# Altium schematic sheet SCH.SchDoc(SCH)
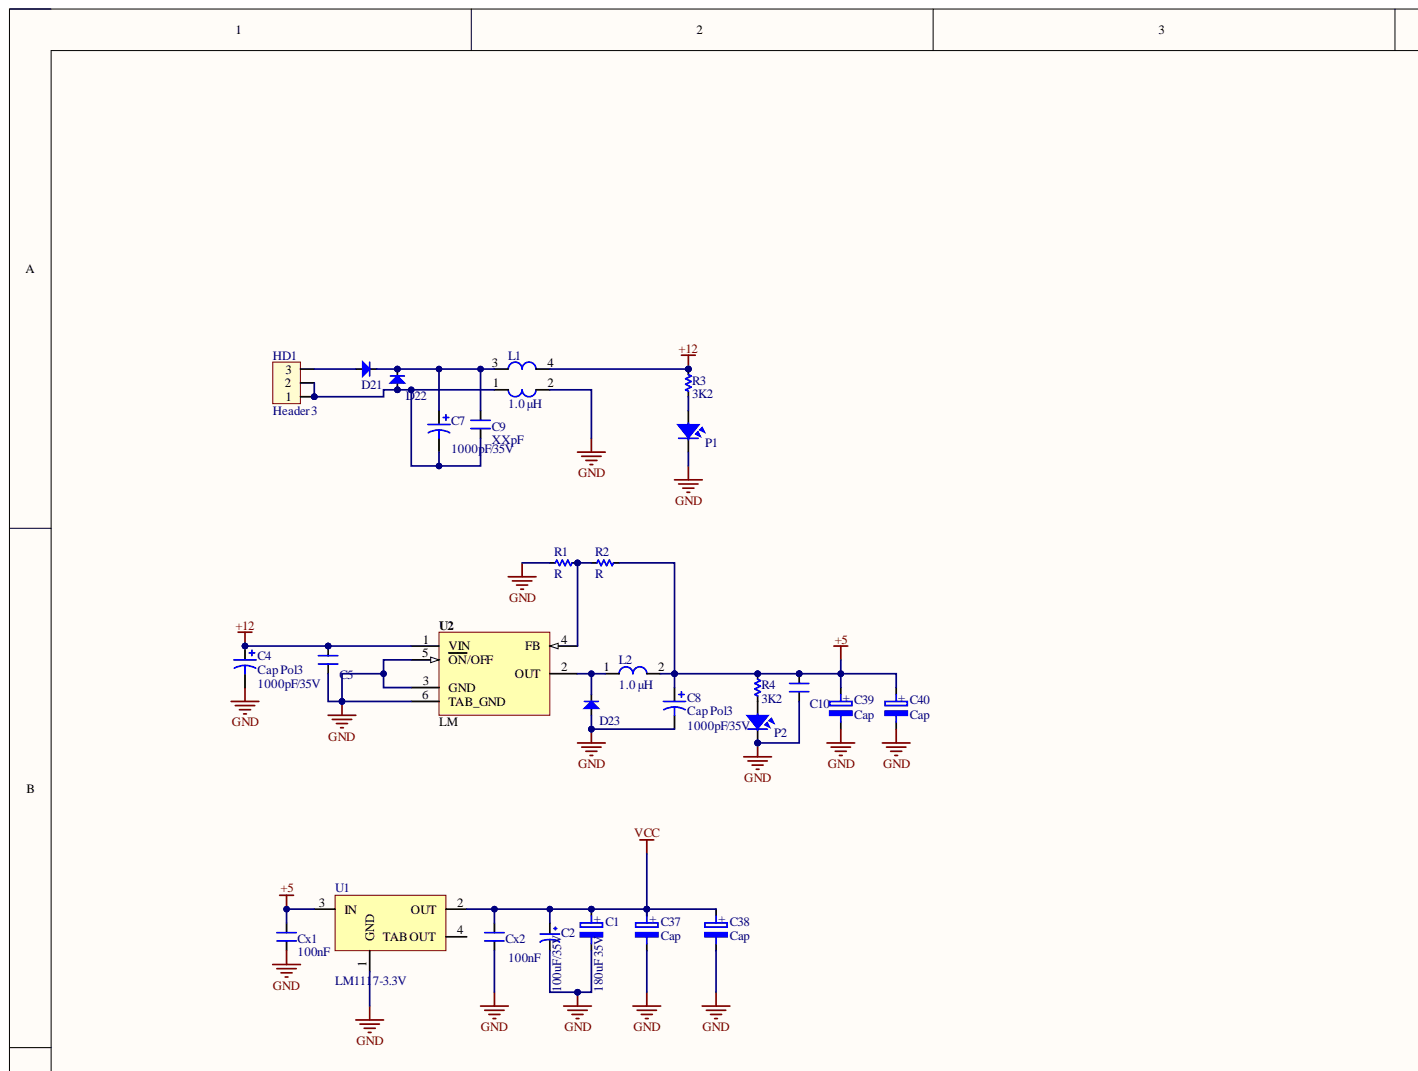
[diagram p0: SCH.SchDoc, top left]
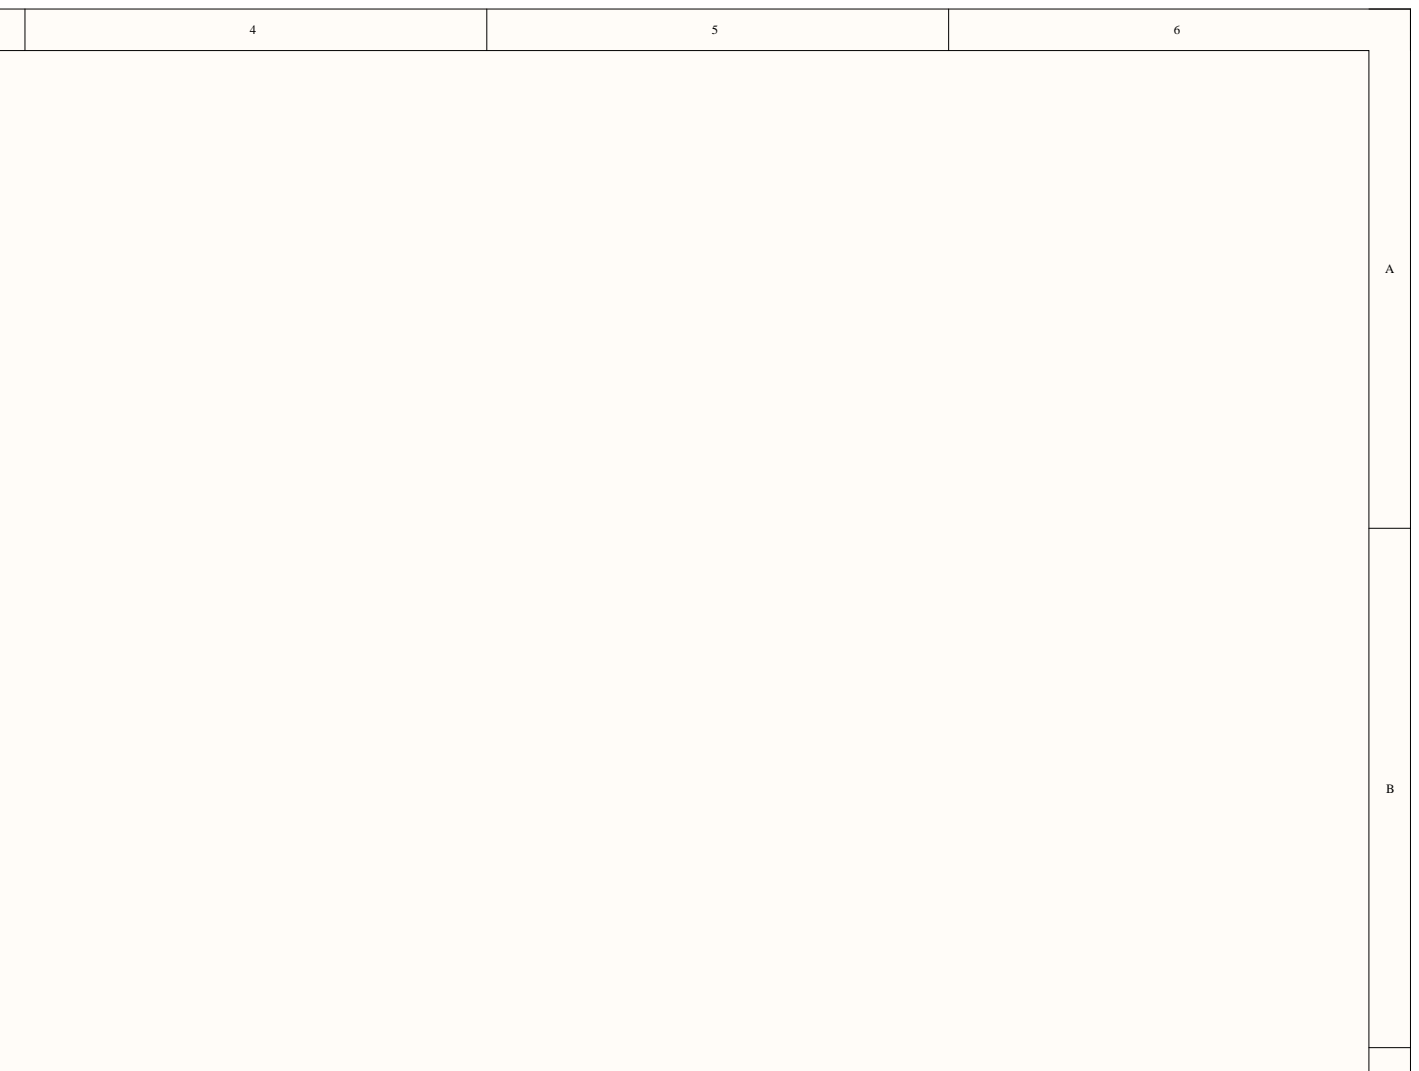
[diagram p1: SCH.SchDoc, top right]
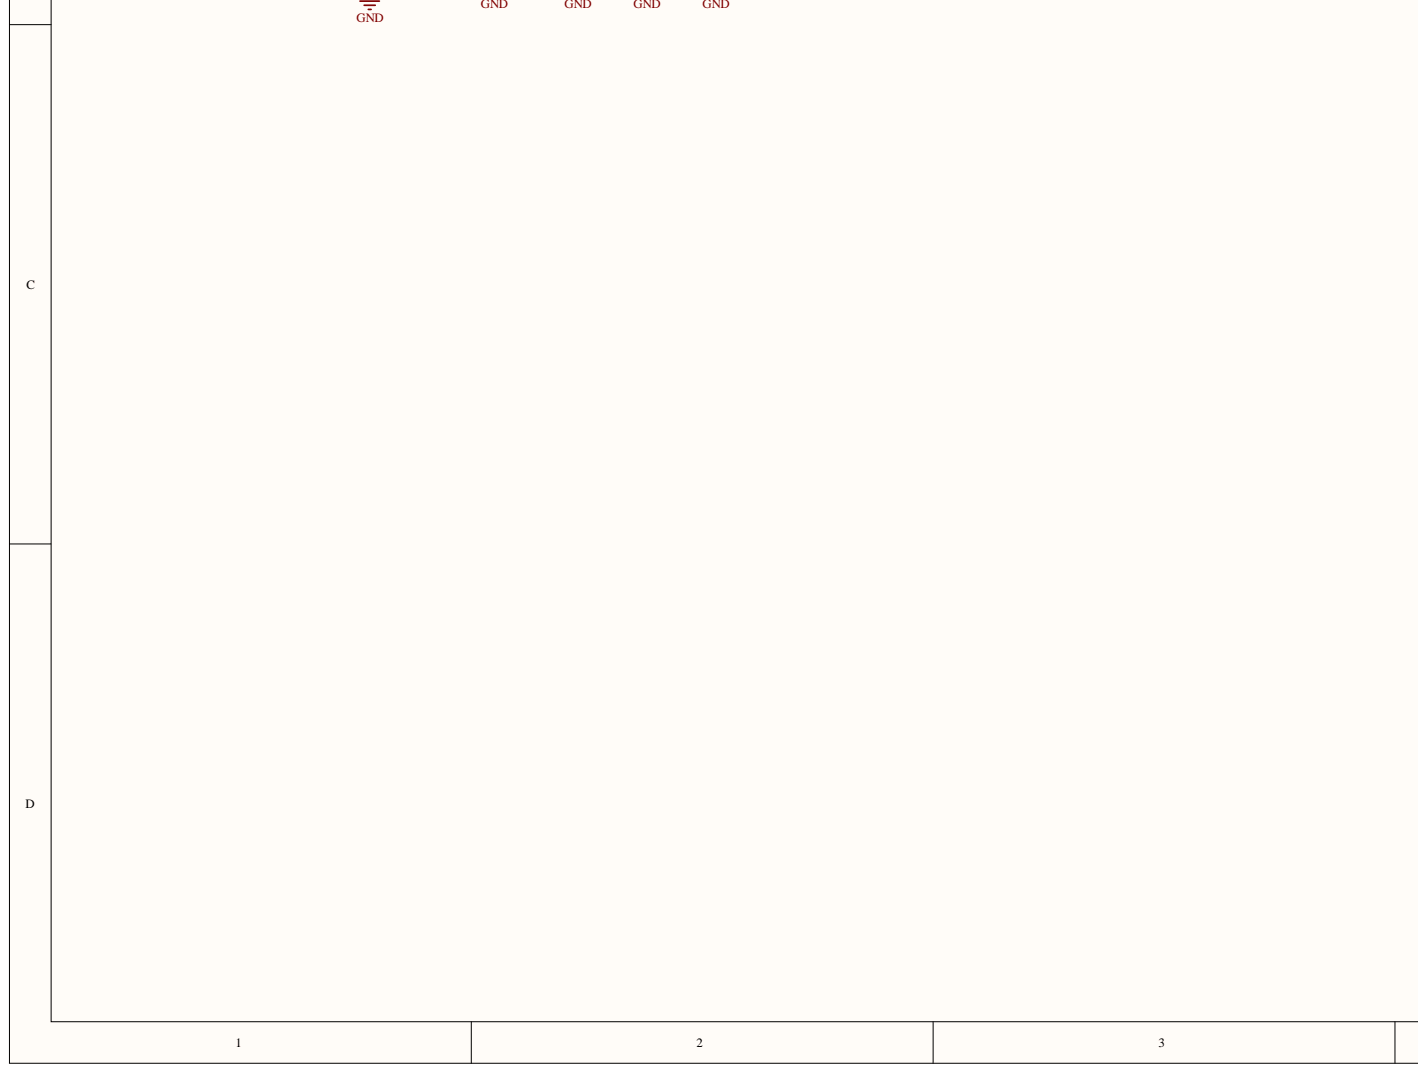
[diagram p2: SCH.SchDoc, bottom left]
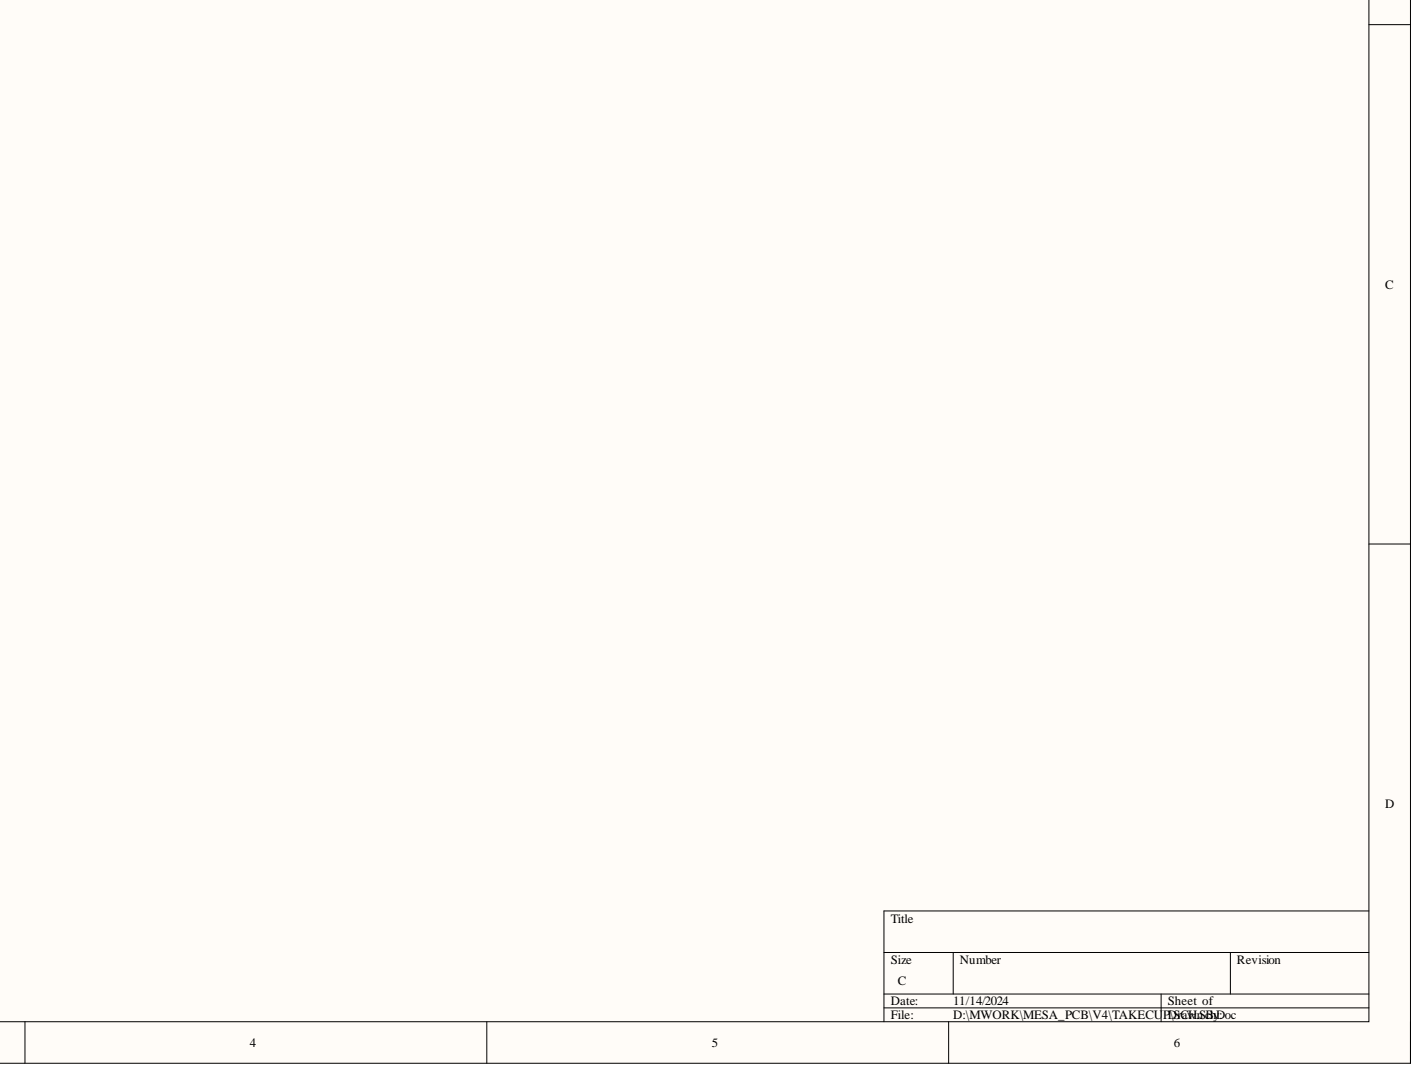
[diagram p3: SCH.SchDoc, bottom right]

## Parts
C1  180uF 35V  [Cap_D]
C2  100uF/35V  [CP1.5/3.4]
C4  Cap Pol3  [CP2/5]
C5  100nF  [CN-SMD-0805]  (value not drawn)
C7  Cap Pol3  [CP2/5]  (value not drawn)
C8  Cap Pol3  [CP2/5]
C9  XXpF  [CN2-T]
C10  100nF  [CN-SMD-0805]  (value not drawn)
C37  Cap  [Cap_1210]
C38  Cap  [Cap_1210]
C39  Cap  [Cap_1210]
C40  Cap  [Cap_1210]
Cx1  100nF  [CN-SMD-0805]
Cx2  100nF  [CN-SMD-0805]
D21  Diode  [DIO-SMD-106-HayDung]  (value not drawn)
D22  Diode  [DIO-SMD-106-HayDung]  (value not drawn)
D23  Diode  [DIO-SMD-106-HayDung]  (value not drawn)
HD1  Header 3  [HED3T]
L1  1.0 μH  [L_UU10.5]
L2  1.0 μH  [L-SMD-M-12x10]
P1  LED  [LED-0805-Green]  (value not drawn)
P2  LED  [LED-0805-Green]  (value not drawn)
R1  R  [RES-SMD-0805]
R2  R  [RES-SMD-0805]
R3  3K2  [RES-SMD-0805]
R4  3K2  [RES-SMD-0805]
U1  LM1117-3.3V  [SOT223]
U2  LM  [TO263 - duplicate]

## Nets
+5: C8.1 C10.2 C39.1 C40.1 Cx1.1 L2.2 R2.1 R4.1 U1.3(IN)
+12: C4.1 C5.2 L1.4 R3.1 U2.1(VIN)
GND: C1.2 C2.2 C4.2 C5.1 C8.2 C10.1 C37.2 C38.2 C39.2 C40.2 Cx1.2 Cx2.2 D23.1 L1.2 P1.2 P2.2 R1.2 U1.1(GND) U2.3(GND) U2.5(ON/OFF) U2.6(TAB_GND)
VCC: C1.1 C2.1 C37.1 C38.1 Cx2.1 U1.2(OUT)
NetC7_1: C7.1 C9.2 D21.2 D22.2 L1.3
NetC7_2: C7.2 C9.1 D22.1 HD1.1 HD1.2 L1.1
NetD21_1: D21.1 HD1.3
NetD23_2: D23.2 L2.1 U2.2(OUT)
NetP1_1: P1.1 R3.2
NetP2_1: P2.1 R4.2
NetR1_1: R1.1 R2.2 U2.4(FB)

Not connected: U1 4(TAB_OUT)

## Library data of the parts
C1 C37-C40 = Cap_tan: Polarized Capacitor (Radial); Component Kind: Standard; Library Name: nhan.SchLib
C2 = CP3: Cap 100uF/35V - 470uF/16V; Description 1: 1000uF/6,3V - 470uF/16V - 330uF - 25V; Description 2: 220uF/35V - 100uF/50V - 63V - 4,7uF/200V; Description 3: 22uF => 33uF100V - 3,3uF => 4,7uF/250V; Description 4: 2,2uF =>3,3uF/350 - 2,2uF/400V - 1uF/450V; Value: 100uF/35V/50V; Component Kind: Standard; Library Name: syhaunguyen.IntLib
C4 C7 = Cap Pol3_5: Polarized Capacitor (Surface Mount); VALUE: C?; Component Kind: Standard; LatestRevisionDate: 17-Jul-2002; LatestRevisionNote: Re-released for DXP Platform.; PackageReference: C0805; Library Name: syhaunguyen.IntLib; Publisher: Altium Limited
C5 C9 C10 Cx1 Cx2 = CN: Value: 33pF; Component Kind: Standard
  C9: Library Name: LIBRARY.IntLib
  C5 C10: Library Name: nhan.SchLib
  Cx1 Cx2: Library Name: BanLinhKien.IntLib
C8 = Cap Pol3_3: Polarized Capacitor (Surface Mount); VALUE: C?; Component Kind: Standard; LatestRevisionDate: 17-Jul-2002; LatestRevisionNote: Re-released for DXP Platform.; PackageReference: C0805; Library Name: syhaunguyen.IntLib; Publisher: Altium Limited
D21-D23 = Diode: Default Diode; Code - JEDEC: DO-214-AB; Value: 1N5007; Component Kind: Standard; ComponentLink1Description: ES1J - STMBL; ComponentLink1URL: https://banlinhkien.vn/goods-8089-es1j-do214-diode-1a-600v-sma-es1j-.html; Published: 8-Jun-2000; Revision: July-2002: Re-released for DXP Platform.; Library Name: nhan.SchLib; Publisher: Altium Limited
HD1 = Hed3: Header 3 (Green); Component Kind: Standard; Value: Header 3; Library Name: syhaunguyen.IntLib
L1 = L-2: Manufacturer: Wurth Elektronik; partNo: 78477010; Component Kind: Standard; ComponentLink1Description: Manufacturer; ComponentLink1URL: http://www.we-online.de; ComponentLink2Description: Datasheet; ComponentLink2URL: http://katalog.we-online.de/pbs/datasheet/78477010.pdf; Created Date: Nov.2015; Inductance: 1.0 μH; Created by: Baojun Chen; Library Name: nhan.SchLib
L2 = L-SMD-1: Manufacturer: Wurth Elektronik; partNo: 78477010; Component Kind: Standard; ComponentLink1Description: Manufacturer; ComponentLink1URL: http://www.we-online.de; ComponentLink2Description: Datasheet; ComponentLink2URL: http://katalog.we-online.de/pbs/datasheet/78477010.pdf; Created Date: Nov.2015; Inductance: 1.0 μH; Created by: Baojun Chen; Library Name: BanLinhKien.IntLib
P1 P2 = LED SMD: Value: LED 3 - 5 ly 2 Colour; Component Kind: Standard; Library Name: BanLinhKien.IntLib
R3 R4 = R: Component Kind: Standard; Library Name: nhan.SchLib
U1 = LM1117: 800mA Low-Dropout Linear Regulator; Component Kind: Standard; Website: http://group3i.net; Creator: Nguyen Ba Bien; Library Name: nhan.SchLib
U2 = LM2596_1: Max Input Voltage: 12 V; Max Output Current: 3 (6.0 peak) A; Max Output Voltage: mV; Category: IC-Power; Component Kind: Standard; Min Input Voltage: mV; Min Output Voltage: mV; Mounting Technology: Surface Mount; Status: Unreleased; Supply Voltage: mV; Temperature Range: -40 to +125 degC; Library Name: nhan.SchLib
R1 R2 = R: Component Kind: Standard; Library Name: nhan.SchLib
